# Altium schematic sheet OpenCar4in1.SchDoc
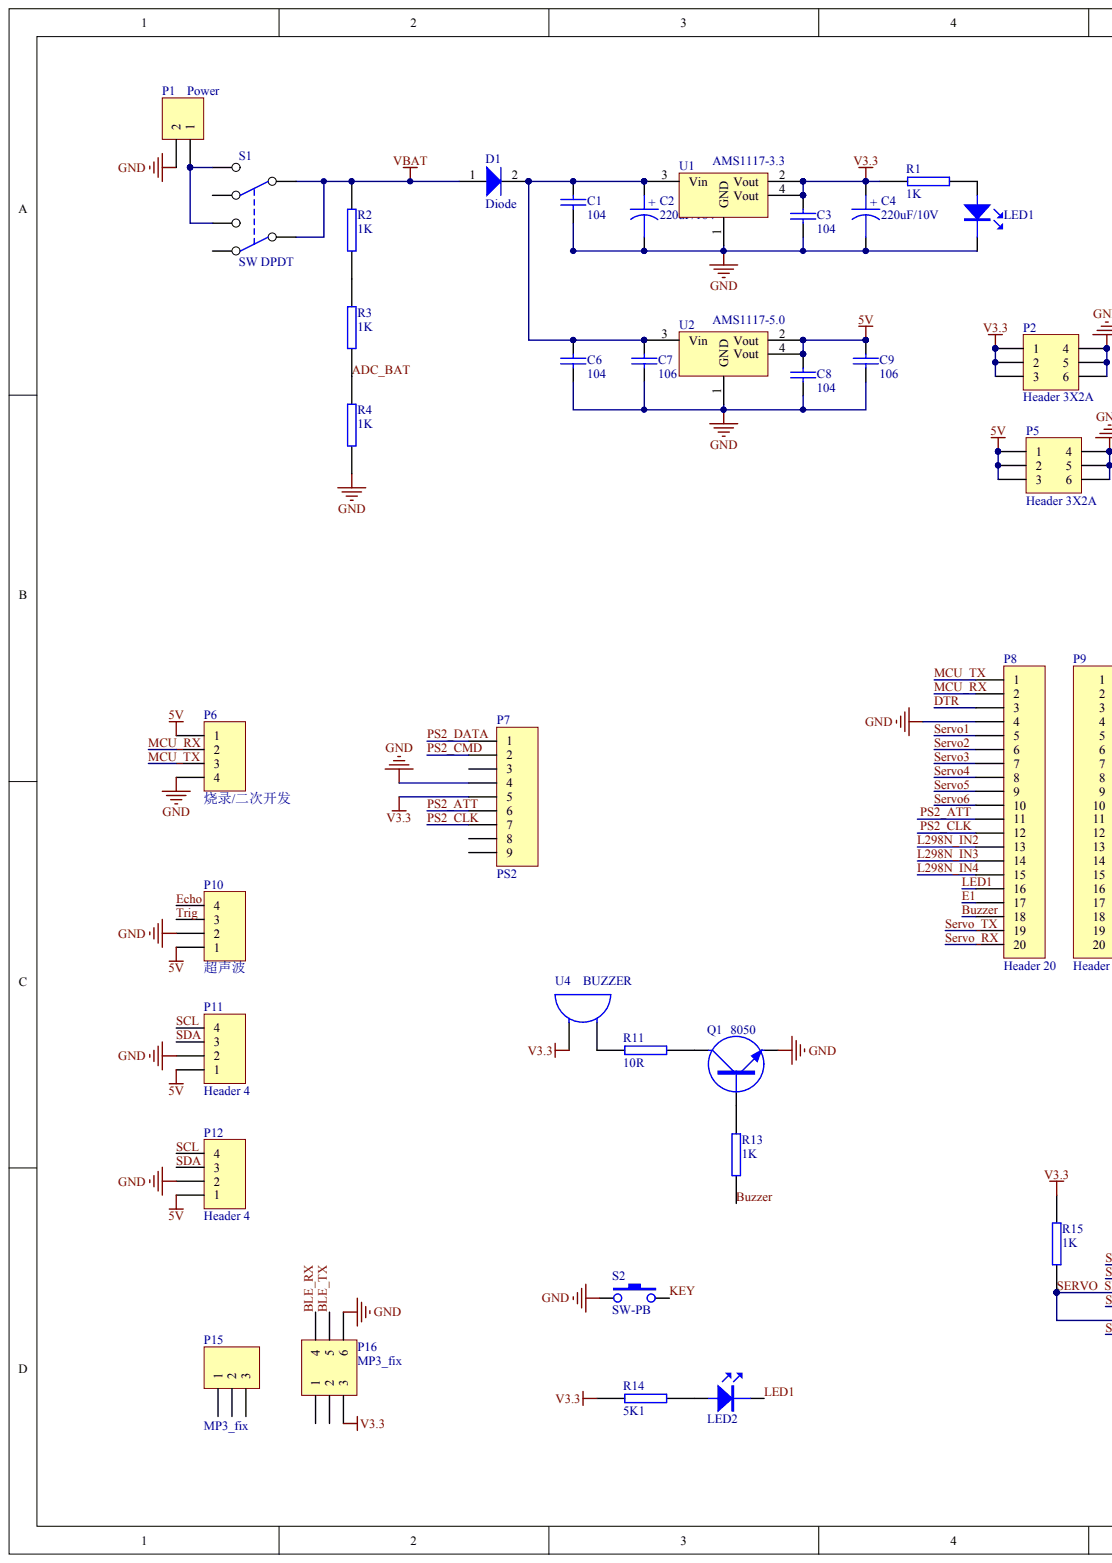
[diagram p0: OpenCar4in1.SchDoc, left]
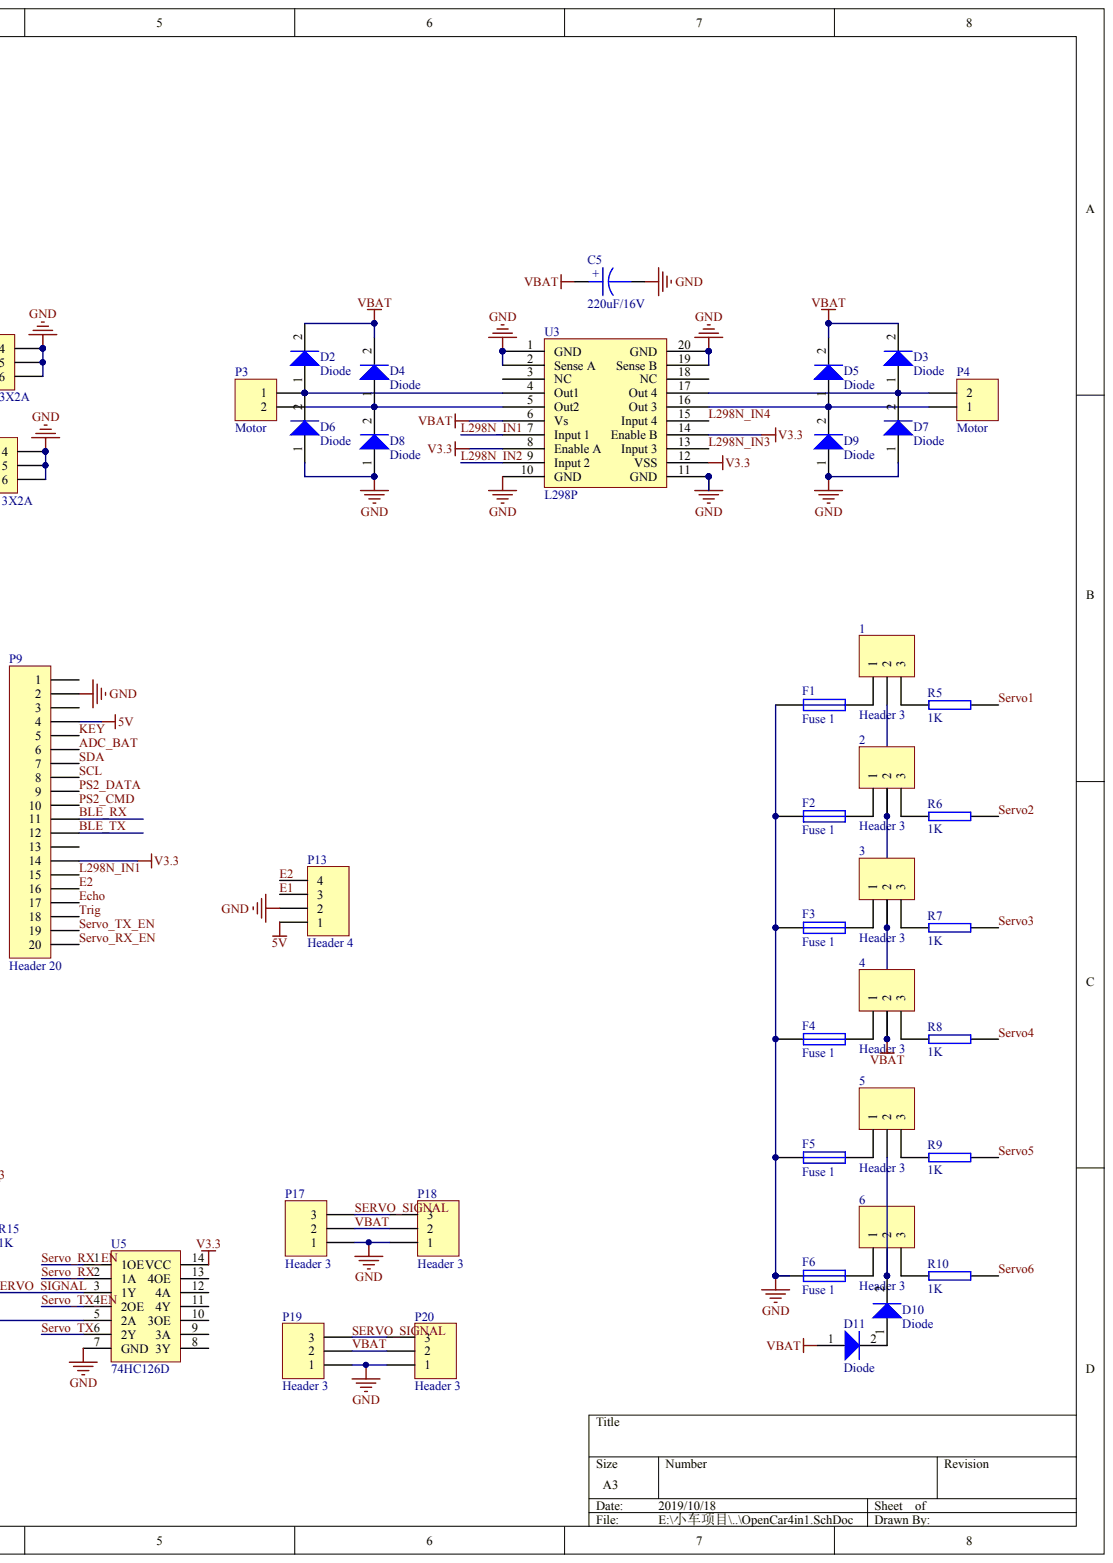
[diagram p1: OpenCar4in1.SchDoc, right]

## Parts
1  Header 3
2  Header 3
3  Header 3
4  Header 3
5  Header 3
6  Header 3
C1  104
C2  220uF/16V
C3  104
C4  220uF/10V
C5  220uF/16V
C6  104
C7  106
C8  104
C9  106
D1  Diode
D2  Diode
D3  Diode
D4  Diode
D5  Diode
D6  Diode
D7  Diode
D8  Diode
D9  Diode
D10  Diode
D11  Diode
F1  Fuse 1
F2  Fuse 1
F3  Fuse 1
F4  Fuse 1
F5  Fuse 1
F6  Fuse 1
LED1  LED
LED2  LED
P1  Power
P2  Header 3X2A
P3  Motor
P4  Motor
P5  Header 3X2A
P6  烧录/二次开发
P7  PS2
P8  Header 20
P9  Header 20
P10  超声波
P11  Header 4
P12  Header 4
P13  Header 4
P15  MP3_fix
P16  MP3_fix
P17  Header 3
P18  Header 3
P19  Header 3
P20  Header 3
Q1  8050
R1  1K
R2  1K
R3  1K
R4  1K
R5  1K
R6  1K
R7  1K
R8  1K
R9  1K
R10  1K
R11  10R
R13  1K
R14  5K1
R15  1K
S1  SW DPDT
S2  SW-PB
U1  AMS1117-3.3
U2  AMS1117-5.0
U3  L298P
U4  BUZZER
U5  74HC126D

## Nets
5V: C8.1 C9.1 P5.1 P5.2 P5.3 P6.1 P9.4 P10.1 P11.1 P12.1 P13.1 U2.2(Vout) U2.4(Vout)
GND: C1.2 C2.2 C3.2 C4.2 C5.2 C6.2 C7.2 C8.2 C9.2 D6.1 D7.1 D8.1 D9.1 F1.1 F2.1 F3.1 F4.1 F5.1 F6.1 LED1.2 P1.2 P2.4 P2.5 P2.6 P5.4 P5.5 P5.6 P6.4 P7.4 P8.4 P9.2 P10.2 P11.2 P12.2 P13.2 P16.6 P17.1 P18.1 P19.1 P20.1 Q1.2 R4.1 S2.1 U1.1(GND) U2.1(GND) U3.1(GND) U3.2(Sense_A) U3.10(GND) U3.11(GND) U3.19(Sense_B) U3.20(GND) U3.21 U5.7(GND)
V3.3: C3.1 C4.1 P2.1 P2.2 P2.3 P7.5 P9.14 P16.3 R1.1 R14.1 R15.2 U1.2(Vout) U1.4(Vout) U3.8(Enable_A) U3.12(VSS) U3.14(Enable_B) U4.1 U5.14(VCC)
ADC_BAT: P9.6 R3.1 R4.2
BLE_RX: P9.11 P16.4
BLE_TX: P9.12 P16.5
BUZZER: P8.18 R13.1
DTR: P8.3
E1: P8.17 P13.3
E2: P9.16 P13.4
ECHO: P9.17 P10.4
KEY: P9.5 S2.2
L298N_IN1: P9.15 U3.7(Input_1)
L298N_IN2: P8.13 U3.9(Input_2)
L298N_IN3: P8.14 U3.13(Input_3)
L298N_IN4: P8.15 U3.15(Input_4)
LED1: LED2.2 P8.16
MCU_RX: P6.2 P8.2
MCU_TX: P6.3 P8.1
PS2_ATT: P7.6 P8.11
PS2_CLK: P7.7 P8.12
PS2_CMD: P7.2 P9.10
PS2_DATA: P7.1 P9.9
SCL: P9.8 P11.4 P12.4
SDA: P9.7 P11.3 P12.3
SERVO1: P8.5 R5.2
SERVO2: P8.6 R6.2
SERVO3: P8.7 R7.2
SERVO4: P8.8 R8.2
SERVO5: P8.9 R9.2
SERVO6: P8.10 R10.2
SERVO_RX: P8.20 U5.2(1A)
SERVO_RX_EN: P9.20 U5.1(1OE)
SERVO_SIGNAL: P17.3 P18.3 P19.3 P20.3 R15.1 U5.3(1Y) U5.5(2A)
SERVO_TX: P8.19 U5.6(2Y)
SERVO_TX_EN: P9.19 U5.4(2OE)
TRIG: P9.18 P10.3
VBAT: 1.2 2.2 3.2 4.2 C5.1 D1.1 D2.2 D3.2 D4.2 D5.2 D11.1 P17.2 P18.2 P19.2 P20.2 R2.2 S1.2 S1.5 U3.6(Vs)
Net1_1: 1.1 F1.2
Net1_3: 1.3 R5.1
Net2_1: 2.1 F2.2
Net2_3: 2.3 R6.1
Net3_1: 3.1 F3.2
Net3_3: 3.3 R7.1
Net4_1: 4.1 F4.2
Net4_3: 4.3 R8.1
Net5_1: 5.1 F5.2
Net5_2: 5.2 6.2 D10.2
Net5_3: 5.3 R9.1
Net6_1: 6.1 F6.2
Net6_3: 6.3 R10.1
NetC1_1: C1.1 C2.1 C6.1 C7.1 D1.2 U1.3(Vin) U2.3(Vin)
NetD2_1: D2.1 D6.2 P3.1 U3.4(Out1)
NetD3_1: D3.1 D7.2 P4.2 U3.17(Out_4)
NetD4_1: D4.1 D8.2 P3.2 U3.5(Out2)
NetD5_1: D5.1 D9.2 P4.1 U3.16(Out_3)
NetD10_1: D10.1 D11.2
NetLED1_1: LED1.1 R1.2
NetLED2_1: LED2.1 R14.2
NetP1_1: P1.1 S1.3 S1.6
NetQ1_1: Q1.1 R13.2
NetQ1_3: Q1.3 R11.2
NetR2_1: R2.1 R3.2
NetR11_1: R11.1 U4.2

Not connected: P7 3 8 9; P9 1 3 13; P15 1 2 3; P16 1 2; S1 1 4; U3 3(NC) 18(NC); U5 8(3Y) 9(3A) 10(3OE) 11(4Y) 12(4A) 13(4OE)

## Library data of the parts
1-6 C1-C9 D1-D11 F1-F6 LED1 LED2 P1-P13 P15-P20 Q1 R1-R11 R13-R15 S1 S2 U1-U5 = (no symbol name)
  1-6 P1-P13 P15-P20: LatestRevisionDate: 17-Jul-2002; LatestRevisionNote: Re-released for DXP Platform.
  D1-D11: Published: 8-Jun-2000; LatestRevisionDate: 17-Jul-2002; LatestRevisionNote: Re-released for DXP Platform.; PackageVersion: Sep-1996; PackageReference: SMC; PackageDescription: DO-214-AB/SMC; 2 C-Bend Leads; Body 7.9 x 5.9 mm, inc. leads (LxW); Code_JEDEC: DO-214-AB
  F1-F6: Published: 8-Jun-2000; LatestRevisionDate: 17-Jul-2002; LatestRevisionNote: Re-released for DXP Platform.; PackageReference: PIN-W2/E2.8; PackageDescription: Thru-Hole; 2 Leads
  1-6 D1-D11 F1-F6 P1-P13 P15-P20: Publisher: Altium Limited
